# Altium schematic sheet sensors_and_dpg_ports.SchDoc
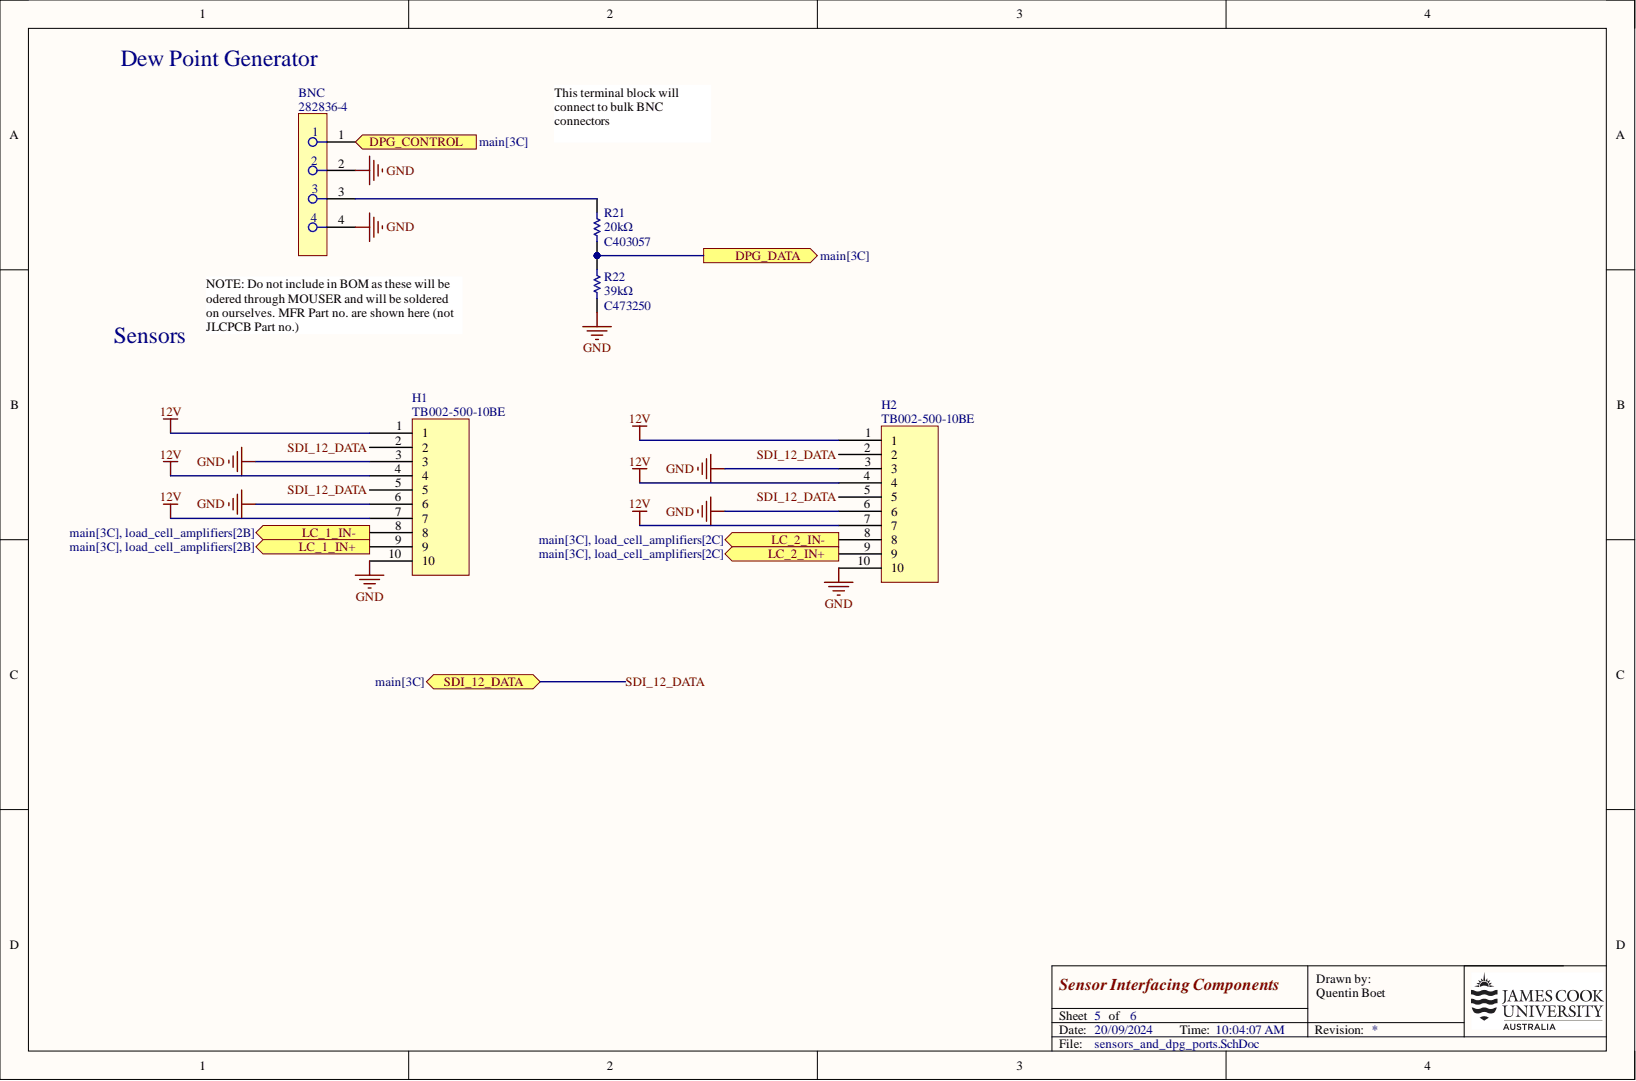
[diagram p0: sensors_and_dpg_ports.SchDoc]

## Parts
BNC  282836-4  [FP-282836-4-MFG]
H1  TB002-500-10BE  [TB002-500-10BE]
H2  TB002-500-10BE  [TB002-500-10BE]
R21  JLCPCB Part: C403057  Resistance: 20kΩ  [RESC1608X55X30ML15T15]  (hidden comment: ERJ-3EKF2002V)
R22  JLCPCB Part: C473250  Resistance: 39kΩ  [RESC1608X55X30LL20T20]  (hidden comment: ERA-3AEB393V)

## Ports
DPG_CONTROL, DPG_DATA, LC_1_IN+, LC_1_IN-, LC_2_IN+, LC_2_IN-, SDI_12_DATA

## Nets
12V: H1.1 H1.4 H1.7 H2.1 H2.4 H2.7
GND: BNC.2 BNC.4 H1.3 H1.6 H1.10 H2.3 H2.6 H2.10 R22.1
DPG_CONTROL: BNC.1 ; port DPG_CONTROL
DPG_DATA: R21.1 R22.2 ; port DPG_DATA
SDI_12_DATA: H1.2 H1.5 H2.2 H2.5 ; port SDI_12_DATA
NetBNC_3: BNC.3 R21.2
NetH1_8: H1.8
NetH1_9: H1.9
NetH2_8: H2.8
NetH2_9: H2.9

## Library data of the parts
BNC = CMP-03790-46505329-1: 282836-4 Connector, Terminal Block; Wire Receptacle; 4 Pos.; 16-30 AWG; 0.197 in. CL; Sid; Manufacturer Lifecycle Status: ACTIVE; Approvals: UL; Connector System: Wire-to-Board; Current: 13.5A; Max Supply Voltage (AC): 300V; Number of Circuits: 4; Number of Levels: 1; Pitch: 5mm; Plating: Brass; Tail Length: 3.5mm; Thread Size: M3; Voltage Rating (AC): 250V; Wire Gauge (Max): 30AWG; Color: Green; Component Kind: Standard; Connector Type: Receptacle; Contact Material: Brass; Contact Plating: Tin; Current Rating: 13.5A; Depth: 8.2mm; ELV: Compliant; Flammability Rating: UL94 V-0; Housing Color: Green; Housing Material: Polyamide; JLCPCB Part: jlcpcb part no.; Lead Free: Lead Free; Lead Pitch: 5mm; Length: 20mm; Library Name: Altium Content Vault; Lifecycle Status: Production; Max Current Rating: 13.5A; Max Voltage Rating (AC): 300V; Mount: Through Hole; NexarPartId: 46505329; Number of Contacts: 4; Number of Poles: 4; Number of Positions: 4; Number of Positions Per Level: 4; Number of Rows: 1; Operating Supply Voltage: 300V; Orientation: Straight; Packaging: Bulk; Radiation Hardening: No; REACH SVHC: Unknown; RoHS: Compliant; Termination: Screw, Solder; Voltage: 300V; Voltage Rating: 300V; Voltage Rating (DC): 250V; Width: 10mm; Wire Gauge (Min): 30AWG
H1 H2 = TB002-500-10BE-1: 2~24 Poles, Screw Type, Horizontal, 5.0 Pitch, 22~12 (AWG), Terminal Block Connector; Number of Positions Per Level: 10; Pitch: 5mm; Plating: Steel; Component Kind: Standard; Contact Plating: Tin; Height: 10mm; JLCPCB Part: jlcpcb part no.; Max Operating Temperature: 105°C; Min Operating Temperature: -40°C; Mounting Technology: Through Hole; Orientation: Horizontal; Width: 7.6mm; Library Name: James Cook University
R21 = CMP-2000-00318-1: Manufacturer: Panasonic; Component Kind: Standard; Library Name: Altium Content Vault; Part Number: ERJ-3EKF2002V
R22 = CMP-2000-00055-1: Manufacturer: Panasonic; Component Kind: Standard; Library Name: Altium Content Vault; Part Number: ERA-3AEB393V
